# Altium schematic sheet IR GESTURE click_v100.SchDoc
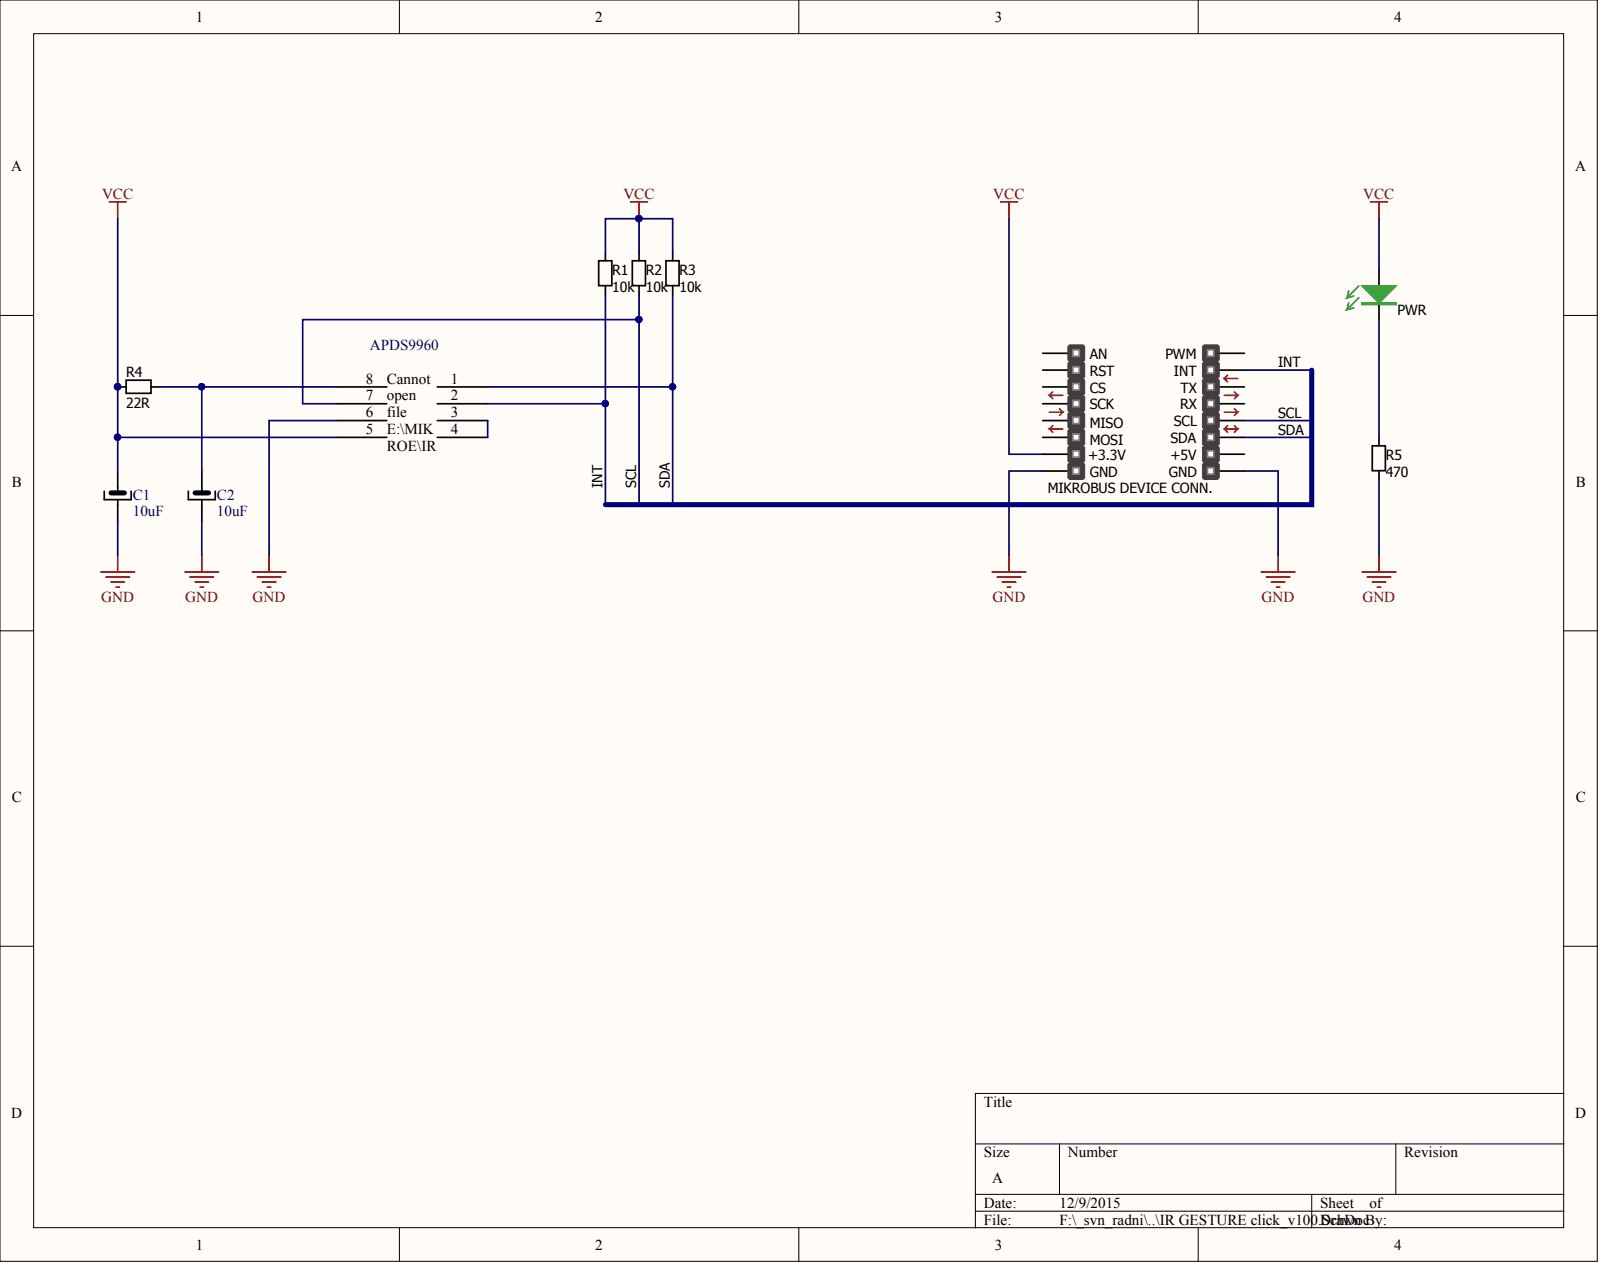
[diagram p0: IR GESTURE click_v100.SchDoc]

## Parts
APDS9960  APDS9960
C1  10uF  [0805 V3]
C2  10uF  [0805 V3]
CON1  MIKROBUS DEVICE CONN.  [MIKRO_BUS_MIN]
PWR  GREEN  [0805 - LED V2]  (value not drawn)
R1  10k  [0805 V3]
R2  10k  [0805 V3]
R3  10k  [0805 V3]
R4  22R  [0805 V3]
R5  470  [0805 V3]

## Nets
GND: APDS9960.6 C1.1 C2.1 CON1.8(GND) CON1.9(GND) R5.2
VCC: APDS9960.5 C1.2 CON1.7(+3.3V) PWR.1 R1.1 R2.1 R3.1 R4.1
INT: APDS9960.2 CON1.15(INT) R1.2
SCL: APDS9960.7 CON1.12(SCL) R2.2
SDA: APDS9960.1 CON1.11(SDA) R3.2
NetAPDS9960_3: APDS9960.3 APDS9960.4
NetAPDS9960_8: APDS9960.8 C2.2 R4.2
NetPWR_2: PWR.2 R5.1
N00003:

Not connected: CON1 1(AN) 2(RST) 3(CS) 4(SCK) 5(MISO) 6(MOSI) 10(+5V) 13(RX) 14(TX) 16(PWM)

## Library data of the parts
C1 C2 = Tantal P: Capacitor; Component Kind: Standard; Tant P type: 10uF; Library Name: GRID EYE click_v100.SchLib
CON1 = MIKROBUS DEVICE CONN.: mikroBUS Target Header; Component Kind: Standard; SMD: No; Library Name: GRID EYE click_v100.SchLib
PWR = LED GREEN: LE diode, Green; Component Kind: Standard; SMD: Yes; Library Name: GRID EYE click_v100.SchLib
R1-R5 = RES: Resistor; Component Kind: Standard; SMD: Yes; Library Name: GRID EYE click_v100.SchLib
APDS9960 = APDS9960: Component Kind: Standard; Library Name: IR GESTURE click_v100.SchLib
